# Altium schematic sheet BLE.SchDoc
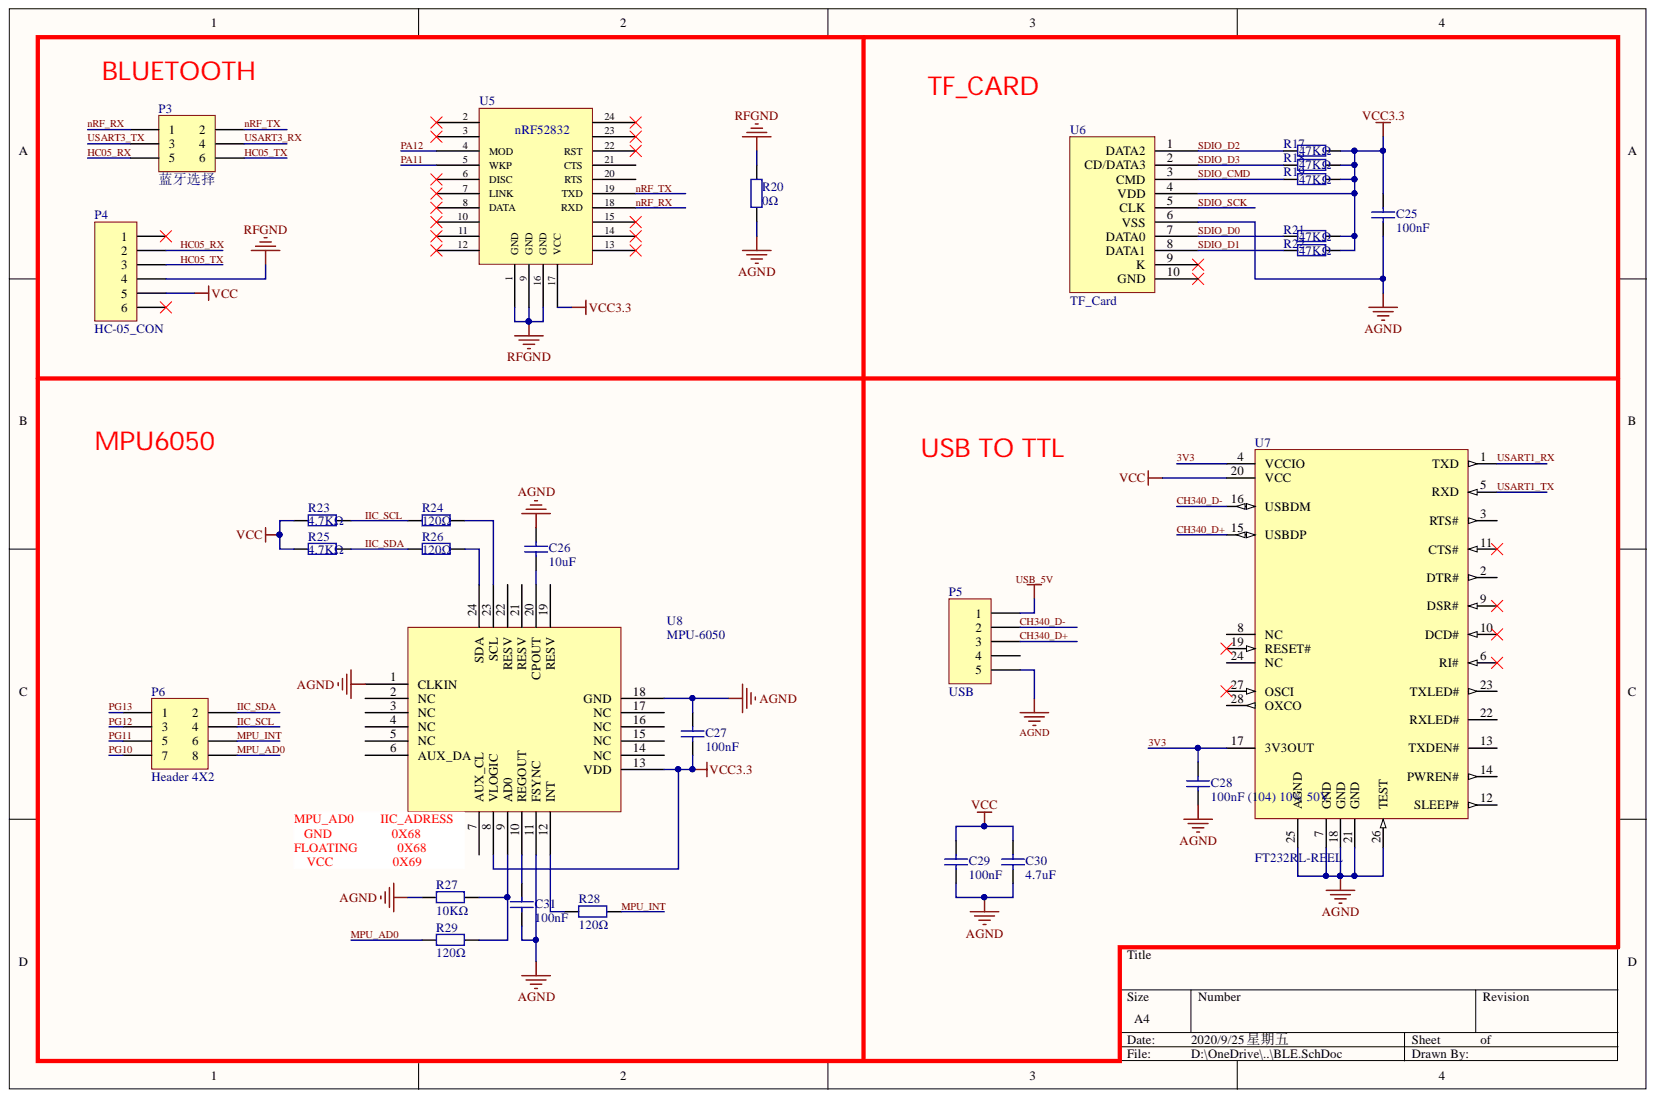
[diagram p0: BLE.SchDoc]

## Parts
C25  100nF  [0402_C]
C26  10uF  [0402_C]
C27  100nF  [0402_C]
C28  100nF (104) 10% 50V  [0603_C]
C29  100nF  [0603_C]
C30  4.7uF  [0603_C]
C31  100nF  [0402_C]
P3  蓝牙选择  [HDR2X3]
P4  HC-05_CON  [HDR1X6]
P5  USB  [MICRO-USB]
P6  Header 4X2  [HDR2X4]
R17  47KΩ  [0402_R]
R18  47KΩ  [0402_R]
R19  47KΩ  [0402_R]
R20  0Ω  [0402_R]
R21  47KΩ  [0402_R]
R22  47KΩ  [0402_R]
R23  4.7KΩ  [0402_R]
R24  120Ω  [0402_R]
R25  4.7KΩ  [0402_R]
R26  120Ω  [0402_R]
R27  10KΩ  [0402_R]
R28  120Ω  [0402_R]
R29  120Ω  [0402_R]
U5  nRF52832  [nRF52832]
U6  TF_Card  [TF_1]
U7  FT232RL-REEL  [SSOP-28_208mil]
U8  MPU-6050  [QFN-24_4x4x05P]

## Nets
AGND: C25.1 C26.2 C27.2 C28.1 C29.1 C30.1 C31.1 P5.5 R20.1 R27.2 U6.6(VSS) U7.7(GND) U7.18(GND) U7.21(GND) U7.25(AGND) U7.26(TEST) U8.1(CLKIN) U8.11(FSYNC) U8.18(GND)
RFGND: P4.4 R20.2 U5.1(GND) U5.9(GND) U5.16(GND)
USB_5V: P5.1
VCC: C29.2 C30.2 P4.5 R23.1 R25.1 U7.20(VCC)
VCC3.3: C25.2 C27.1 R17.2 R18.2 R19.2 R21.2 R22.2 U5.17(VCC) U6.4(VDD) U8.8(VLOGIC) U8.13(VDD)
3V3: C28.2 U7.4(VCCIO) U7.17(3V3OUT)
CH340_D+: P5.3 U7.15(USBDP)
CH340_D-: P5.2 U7.16(USBDM)
HC05_RX: P3.5 P4.2
HC05_TX: P3.6 P4.3
IIC_SCL: P6.4 R23.2 R24.2
IIC_SDA: P6.2 R25.2 R26.2
MPU_AD0: P6.8 R29.2
MPU_INT: P6.6 R28.1
nRF_RX: P3.1 U5.18(RXD)
nRF_TX: P3.2 U5.19(TXD)
PA11: U5.5(WKP)
PA12: U5.4(MOD)
PG10: P6.7
PG11: P6.5
PG12: P6.3
PG13: P6.1
SDIO_CMD: R19.1 U6.3(CMD)
SDIO_D0: R21.1 U6.7(DATA0)
SDIO_D1: R22.1 U6.8(DATA1)
SDIO_D2: R17.1 U6.1(DATA2)
SDIO_D3: R18.1 U6.2(CD/DATA3)
SDIO_SCK: U6.5(CLK)
USART1_RX: U7.1(TXD)
USART1_TX: U7.5(RXD)
USART3_RX: P3.4
USART3_TX: P3.3
NetC26_1: C26.1 U8.20(CPOUT)
NetC31_2: C31.2 U8.10(REGOUT)
NetR24_1: R24.1 U8.23(SCL)
NetR26_1: R26.1 U8.24(SDA)
NetR27_1: R27.1 R29.1 U8.9(AD0)
NetR28_2: R28.2 U8.12(INT)

Not connected: P4 1 6; P5 4; U5 2 3 6(DISC) 7(LINK) 8(DATA) 10 11 12 13 14 15 20(RTS) 21(CTS) 22(RST) 23 24; U6 9(K) 10(GND); U7 2(DTR#) 3(RTS#) 6(RI#) 8(NC) 9(DSR#) 10(DCD#) 11(CTS#) 12(SLEEP#) 13(TXDEN#) 14(PWREN#) 19(RESET#) 22(RXLED#) 23(TXLED#) 24(NC) 27(OSCI) 28(OXCO); U8 2(NC) 3(NC) 4(NC) 5(NC) 6(AUX_DA) 7(AUX_CL) 14(NC) 15(NC) 16(NC) 17(NC) 19(RESV) 21(RESV) 22(RESV)

## Library data of the parts
C25 C27 C31 = 0402 100nF (104) 10% 16V: 贴片电容; Component Kind: Standard; ComponentLink1Description: 供应商链接; ComponentLink1URL: http://www.szlcsc.com/product/details_1877.html; Library Name: Miscellaneous Devices LC.IntLib; Package: 0402; Supplier: LC; SuppliersPartNumber: C1525
C26 = 0402 10uF (106) 20% 6.3V: 贴片电容; Component Kind: Standard; ComponentLink1Description: 供应商链接; ComponentLink1URL: http://www.szlcsc.com/product/details_16204.html; Library Name: Miscellaneous Devices LC.IntLib; Package: 0402; Supplier: LC; SuppliersPartNumber: C15525
C28 C29 = 0603 100nF (104) 10% 50V: 贴片电容; Component Kind: Standard; ComponentLink1Description: 供应商链接; ComponentLink1URL: http://www.szlcsc.com/product/details_15331.html; Library Name: Miscellaneous Devices LC.IntLib; Package: 0603; Supplier: LC; SuppliersPartNumber: C14663
C30 = 0603 4.7uF (475) 10% 16V: 贴片电容; Component Kind: Standard; ComponentLink1Description: 供应商链接; ComponentLink1URL: http://www.szlcsc.com/product/details_20375.html; Library Name: Miscellaneous Devices LC.IntLib; Package: 0603; Supplier: LC; SuppliersPartNumber: C19666
P3 = Header 3X2: Header, 3-Pin, Dual row; Component Kind: Standard; LatestRevisionDate: 17-Jul-2002; LatestRevisionNote: Re-released for DXP Platform.; Library Name: Miscellaneous Connectors.IntLib; Signal Integrity: Connector; Publisher: Altium Limited
P4 = Header 6: Header, 6-Pin; Component Kind: Standard; LatestRevisionDate: 17-Jul-2002; LatestRevisionNote: Re-released for DXP Platform.; Library Name: Miscellaneous Connectors.IntLib; Signal Integrity: Connector; Publisher: Altium Limited
P5 = Header 5: Header, 5-Pin; Component Kind: Standard; LatestRevisionDate: 17-Jul-2002; LatestRevisionNote: Re-released for DXP Platform.; Library Name: Miscellaneous Connectors.IntLib; Signal Integrity: Connector; Publisher: Altium Limited
P6 = Header 4X2: Header, 4-Pin, Dual row; Component Kind: Standard; LatestRevisionDate: 17-Jul-2002; LatestRevisionNote: Re-released for DXP Platform.; Library Name: Miscellaneous Connectors.IntLib; Signal Integrity: Connector; Publisher: Altium Limited
R17-R19 R21 R22 = 0402 47KΩ (4702) 1%: 贴片电阻; Component Kind: Standard; ComponentLink1Description: 供应商链接; ComponentLink1URL: http://www.szlcsc.com/product/details_26535.html; Library Name: Miscellaneous Devices LC.IntLib; Package: 0402; Supplier: LC; SuppliersPartNumber: C25792
R20 = 0402 0Ω (0R0) 1%: 贴片电阻; Component Kind: Standard; ComponentLink1Description: 供应商链接; ComponentLink1URL: http://www.szlcsc.com/product/details_17853.html; Library Name: Miscellaneous Devices LC.IntLib; Package: 0402; Supplier: LC; SuppliersPartNumber: C17168
R23 R25 = 0402 4.7KΩ (4701) 1%: 贴片电阻; Component Kind: Standard; ComponentLink1Description: 供应商链接; ComponentLink1URL: http://www.szlcsc.com/product/details_26643.html; Library Name: Miscellaneous Devices LC.IntLib; Package: 0402; Supplier: LC; SuppliersPartNumber: C25900
R24 R26 R28 R29 = 0402 120Ω (1200) 1%: 贴片电阻; Component Kind: Standard; ComponentLink1Description: 供应商链接; ComponentLink1URL: http://www.szlcsc.com/product/details_25822.html; Library Name: Miscellaneous Devices LC.IntLib; Package: 0402; Supplier: LC; SuppliersPartNumber: C25079
R27 = 0402 10KΩ (1002) 1%: 贴片电阻; Component Kind: Standard; ComponentLink1Description: 供应商链接; ComponentLink1URL: http://www.szlcsc.com/product/details_26487.html; Library Name: Miscellaneous Devices LC.IntLib; Package: 0402; Supplier: LC; SuppliersPartNumber: C25744
U7 = FT232RL-REEL_[C8690]: USB; Component Kind: Standard; ComponentLink1Description: 供应商链接; ComponentLink1URL: http://www.szlcsc.com/product/details_9194.html; Library Name: Miscellaneous Devices LC.IntLib; Package: SSOP-28_208mil; Supplier: LC; SuppliersPartNumber: C8690
U8 = MPU-6050_[C24112]: 传感器; Component Kind: Standard; ComponentLink1Description: 供应商链接; ComponentLink1URL: http://www.szlcsc.com/product/details_24852.html; Library Name: Miscellaneous Devices LC.IntLib; Package: QFN-24_4x4x05P; Supplier: LC; SuppliersPartNumber: C24112
U5 = nRF52832: Component Kind: Standard; Library Name: EEG.SchLib
U6 = TF_Card: Component Kind: Standard; Library Name: EEG.SchLib
